# Altium schematic sheet KDT3254SIM-S7P-主控驱动板.SchDoc
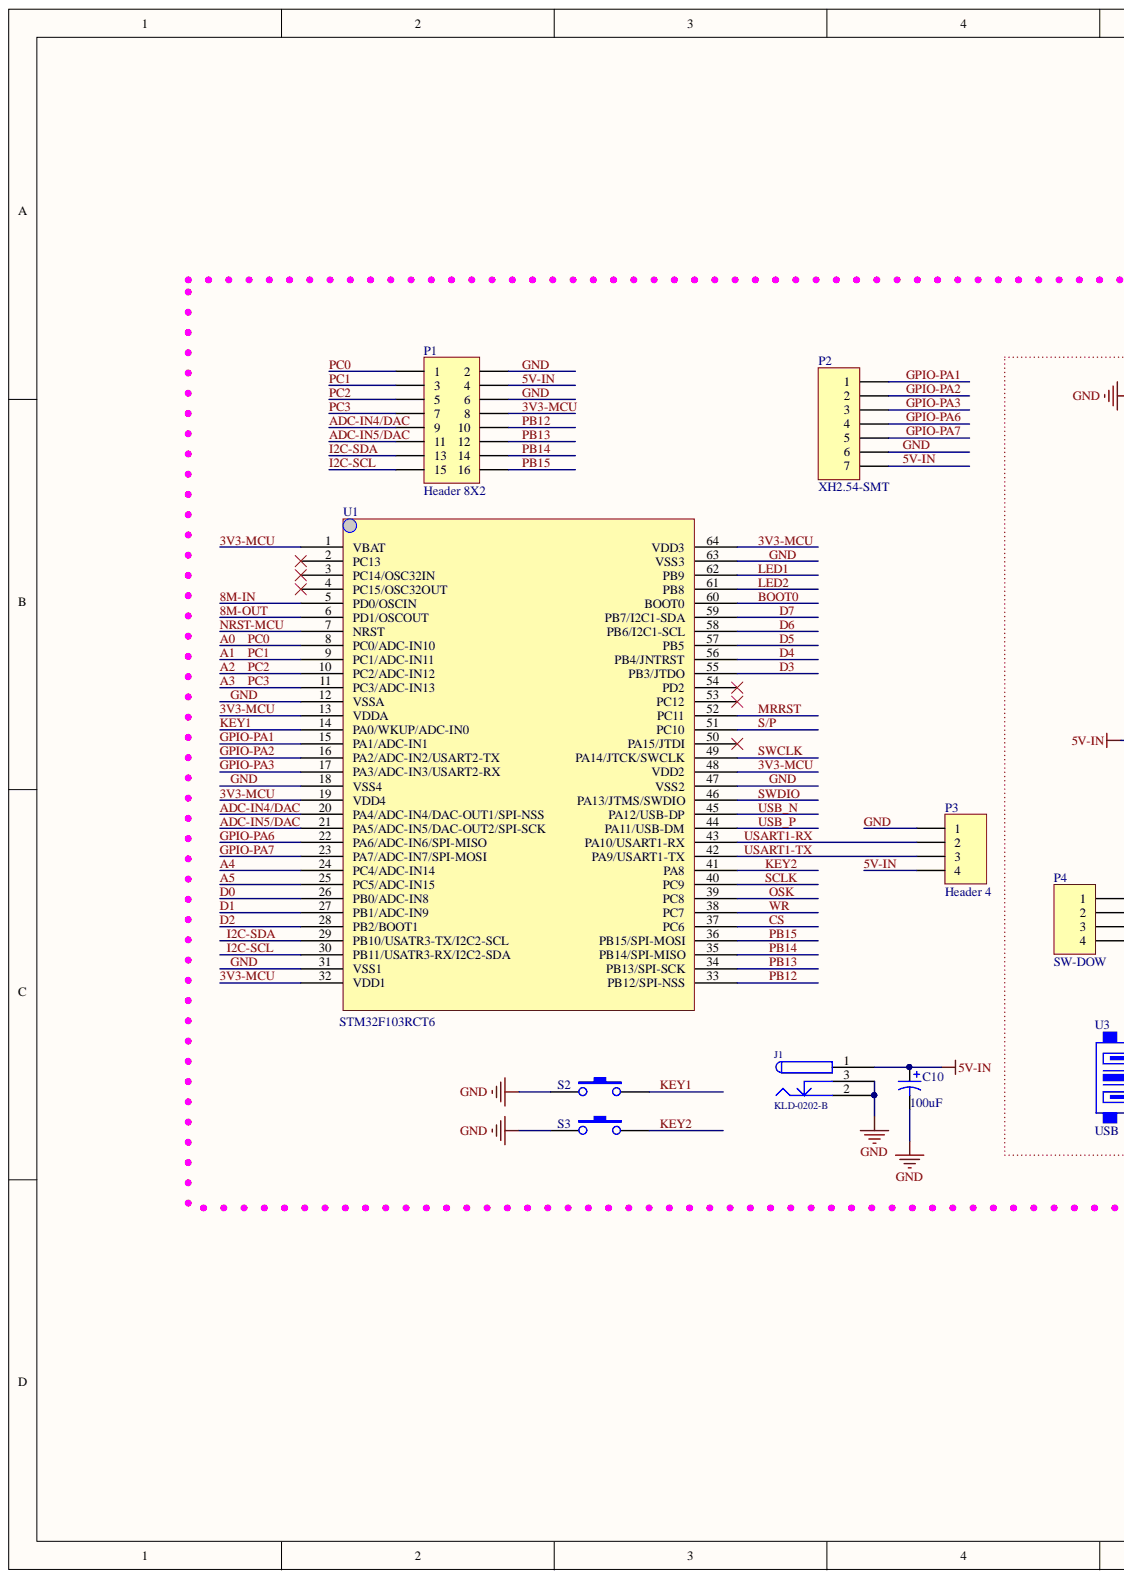
[diagram p0: KDT3254SIM-S7P-主控驱动板.SchDoc, left]
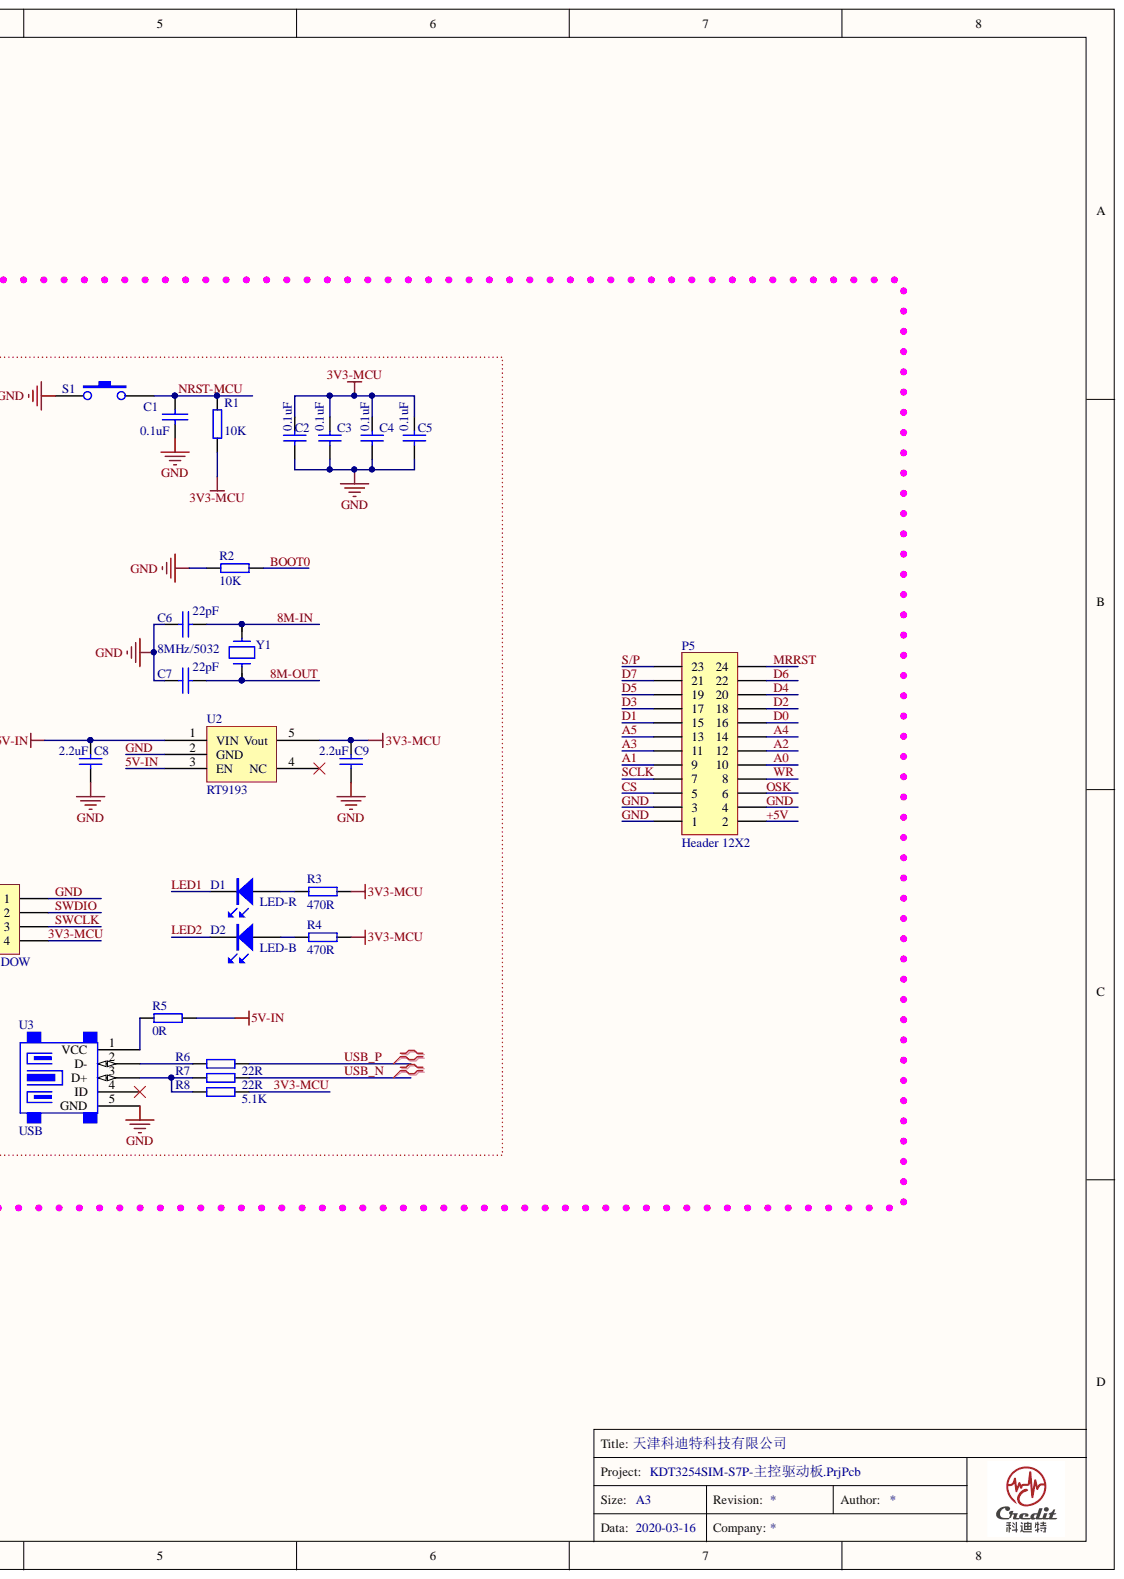
[diagram p1: KDT3254SIM-S7P-主控驱动板.SchDoc, right]

## Parts
C1  0.1uF  [C0603]
C2  value 0.1uF  [C0603]
C3  value 0.1uF  [C0603]
C4  value 0.1uF  [C0603]
C5  value 0.1uF  [C0603]
C6  22pF  [C0603]
C7  22pF  [C0603]
C8  value 2.2uF  [C1206]
C9  value 2.2uF  [C1206]
C10  value 100uF  [C7343]
D1  LED-R  [LED0805R]
D2  LED-B  [LED0805R]
J1  KLD-0202-B  [DC-050]
P1  Header 8X2  [HDR2.54-LI-2x8P]
P2  XH2.54-SMT  [XH2.54-WS-7P]
P3  Header 4  [XH2.54-WS-4P]
P4  SW-DOW  [HDR2.54-LI-4P]
P5  Header 12X2  [IDC2.54-LI-2x12P]
R1  10K  [R0603]
R2  10K  [R0603]
R3  470R  [R0603]
R4  470R  [R0603]
R5  0R  [R0603]
R6  22R  [R0603]
R7  22R  [R0603]
R8  5.1K  [R0603]
S1  RST  [KEY5*5]  (value not drawn)
S2  RST  [KEY6X6SMD]  (value not drawn)
S3  RST  [KEY6X6SMD]  (value not drawn)
U1  STM32F103RCT6  [LQFP64 10x10_M]
U2  RT9193  [SOT23-5]
U3  USB  [USB-MINI]
Y1  8MHz/5032  [JINZHEN_8M]

## Nets
3V3-MCU: C2.2 C3.2 C4.2 C5.2 C9.2 P1.8 P4.4 R1.2 R3.2 R4.2 R8.2 U1.1(VBAT) U1.13(VDDA) U1.19(VDD4) U1.32(VDD1) U1.48(VDD2) U1.64(VDD3) U2.5(Vout)
5V-IN: C8.2 C10.1 J1.1 P1.4 P2.7 P3.4 R5.2 U2.1(VIN) U2.3(EN)
8M-IN: C6.1 U1.5(PD0/OSCIN) Y1.2
8M-OUT: C7.1 U1.6(PD1/OSCOUT) Y1.1
+5V: P5.2
A0: P1.1 P5.10 U1.8(PC0/ADC-IN10)
A1: P1.3 P5.9 U1.9(PC1/ADC-IN11)
A2: P1.5 P5.12 U1.10(PC2/ADC-IN12)
A3: P1.7 P5.11 U1.11(PC3/ADC-IN13)
A4: P5.14 U1.24(PC4/ADC-IN14)
A5: P5.13 U1.25(PC5/ADC-IN15)
ADC-IN4/DAC: P1.9 U1.20(PA4/ADC-IN4/DAC-OUT1/SPI-NSS)
ADC-IN5/DAC: P1.11 U1.21(PA5/ADC-IN5/DAC-OUT2/SPI-SCK)
BOOT0: R2.2 U1.60(BOOT0)
CS: P5.5 U1.37(PC6)
D0: P5.16 U1.26(PB0/ADC-IN8)
D1: P5.15 U1.27(PB1/ADC-IN9)
D2: P5.18 U1.28(PB2/BOOT1)
D3: P5.17 U1.55(PB3/JTDO)
D4: P5.20 U1.56(PB4/JNTRST)
D5: P5.19 U1.57(PB5)
D6: P5.22 U1.58(PB6/I2C1-SCL)
D7: P5.21 U1.59(PB7/I2C1-SDA)
GND: C1.2 C2.1 C3.1 C4.1 C5.1 C6.2 C7.2 C8.1 C9.1 C10.2 J1.2 J1.3 P1.2 P1.6 P2.6 P3.1 P4.1 P5.1 P5.3 P5.4 R2.1 S1.1 S2.1 S3.1 U1.12(VSSA) U1.18(VSS4) U1.31(VSS1) U1.47(VSS2) U1.63(VSS3) U2.2(GND) U3.5(GND)
GPIO-PA1: P2.1 U1.15(PA1/ADC-IN1)
GPIO-PA2: P2.2 U1.16(PA2/ADC-IN2/USART2-TX)
GPIO-PA3: P2.3 U1.17(PA3/ADC-IN3/USART2-RX)
GPIO-PA6: P2.4 U1.22(PA6/ADC-IN6/SPI-MISO)
GPIO-PA7: P2.5 U1.23(PA7/ADC-IN7/SPI-MOSI)
I2C-SCL: P1.15 U1.30(PB11/USATR3-RX/I2C2-SDA)
I2C-SDA: P1.13 U1.29(PB10/USATR3-TX/I2C2-SCL)
KEY1: S2.2 U1.14(PA0/WKUP/ADC-IN0)
KEY2: S3.2 U1.41(PA8)
LED1: D1.2 U1.62(PB9)
LED2: D2.2 U1.61(PB8)
MRRST: P5.24 U1.52(PC11)
NRST-MCU: C1.1 R1.1 S1.2 U1.7(NRST)
OSK: P5.6 U1.39(PC8)
PB12: P1.10 U1.33(PB12/SPI-NSS)
PB13: P1.12 U1.34(PB13/SPI-SCK)
PB14: P1.14 U1.35(PB14/SPI-MISO)
PB15: P1.16 U1.36(PB15/SPI-MOSI)
S/P: P5.23 U1.51(PC10)
SCLK: P5.7 U1.40(PC9)
SWCLK: P4.3 U1.49(PA14/JTCK/SWCLK)
SWDIO: P4.2 U1.46(PA13/JTMS/SWDIO)
USART1-RX: P3.2 U1.43(PA10/USART1-RX)
USART1-TX: P3.3 U1.42(PA9/USART1-TX)
USB_N: R7.2 U1.45(PA12/USB-DP)
USB_P: R6.2 U1.44(PA11/USB-DM)
WR: P5.8 U1.38(PC7)
NetD1_1: D1.1 R3.1
NetD2_1: D2.1 R4.1
NetR5_1: R5.1 U3.1(VCC)
NetR6_1: R6.1 U3.2(D-)
NetR7_1: R7.1 R8.1 U3.3(D+)

Not connected: U1 2(PC13) 3(PC14/OSC32IN) 4(PC15/OSC32OUT) 50(PA15/JTDI) 53(PC12) 54(PD2); U2 4(NC); U3 4(ID)

## Library data of the parts
C1 C6 C7 = CAP: Capacitor; Component Kind: Standard
C2-C5 C8 C9 = Cap: Capacitor; Component Kind: Standard; LatestRevisionDate: 17-Jul-2002; LatestRevisionNote: Re-released for DXP Platform.; Library Name: Miscellaneous Devices.IntLib; PackageDescription: Radial Cap, Thru-Hole; 2 Leads; 0.3 in Pin Spacing; PackageReference: RAD-0.3; Published: 8-Jun-2000; Signal Integrity: Cap; Simulation: CAP; Publisher: Altium Limited
C10 = Cap Pol1: Polarized Capacitor (Radial); Component Kind: Standard; LatestRevisionDate: 17-Jul-2002; LatestRevisionNote: Re-released for DXP Platform.; Library Name: Miscellaneous Devices.IntLib; PackageDescription: Polarized Capacitor (Radial); 2 Leads; PackageReference: RB7.6-15; Published: 8-Jun-2000; Signal Integrity: Cap Pol; Simulation: CAP; Publisher: Altium Limited
D1 D2 = LED: Component Kind: Standard; Library Name: Miscellaneous Devices.SchLib
J1 = KLD-0202-B: Low Voltage Power Supply Connector; Manufacturer: Kycon; AEMS Code: 3510211; Component Kind: Standard; Library Name: My3D.SCHLIB; PCB3D: power_jack
P1 = Header 8X2: Header, 8-Pin, Dual row; Component Kind: Standard; LatestRevisionDate: 17-Jul-2002; LatestRevisionNote: Re-released for DXP Platform.; Signal Integrity: Connector; Library Name: Xiaowei.SchLib; Publisher: Altium Limited
P2 = Header 7: Header, 7-Pin; Component Kind: Standard; LatestRevisionDate: 17-Jul-2002; LatestRevisionNote: Re-released for DXP Platform.; Signal Integrity: Connector; Library Name: Xiaowei.SchLib; Publisher: Altium Limited
P3 P4 = Header 4: Header, 4-Pin; Component Kind: Standard; LatestRevisionDate: 17-Jul-2002; LatestRevisionNote: Re-released for DXP Platform.; Signal Integrity: Connector; Library Name: Xiaowei.SchLib; Publisher: Altium Limited
P5 = Header 12X2: Header, 12-Pin, Dual row; Component Kind: Standard; LatestRevisionDate: 17-Jul-2002; LatestRevisionNote: Re-released for DXP Platform.; Library Name: Miscellaneous Connectors.IntLib; Signal Integrity: Connector; Publisher: Altium Limited
R1 R2 = RES2: Component Kind: Standard; Library Name: STM32最小系统板.SCHLIB
R3-R8 = Res: Component Kind: Standard; Library Name: Miscellaneous Devices.SchLib
S1-S3 = SW-PB: Component Kind: Standard
U1 = STM32F103RCT6-64PIN: Component Kind: Standard; Library Name: Xiaowei.SchLib
U2 = TLV700xx: Component Kind: Standard; Library Name: Xiaowei.SchLib
U3 = USB-Mini: Component Kind: Standard; Description: USB; Library Name: Xiaowei.SchLib
Y1 = CRYSTAL: Component Kind: Standard; Description: Crystal
